# Altium schematic sheet MCU_related.SchDoc(MCU_related)
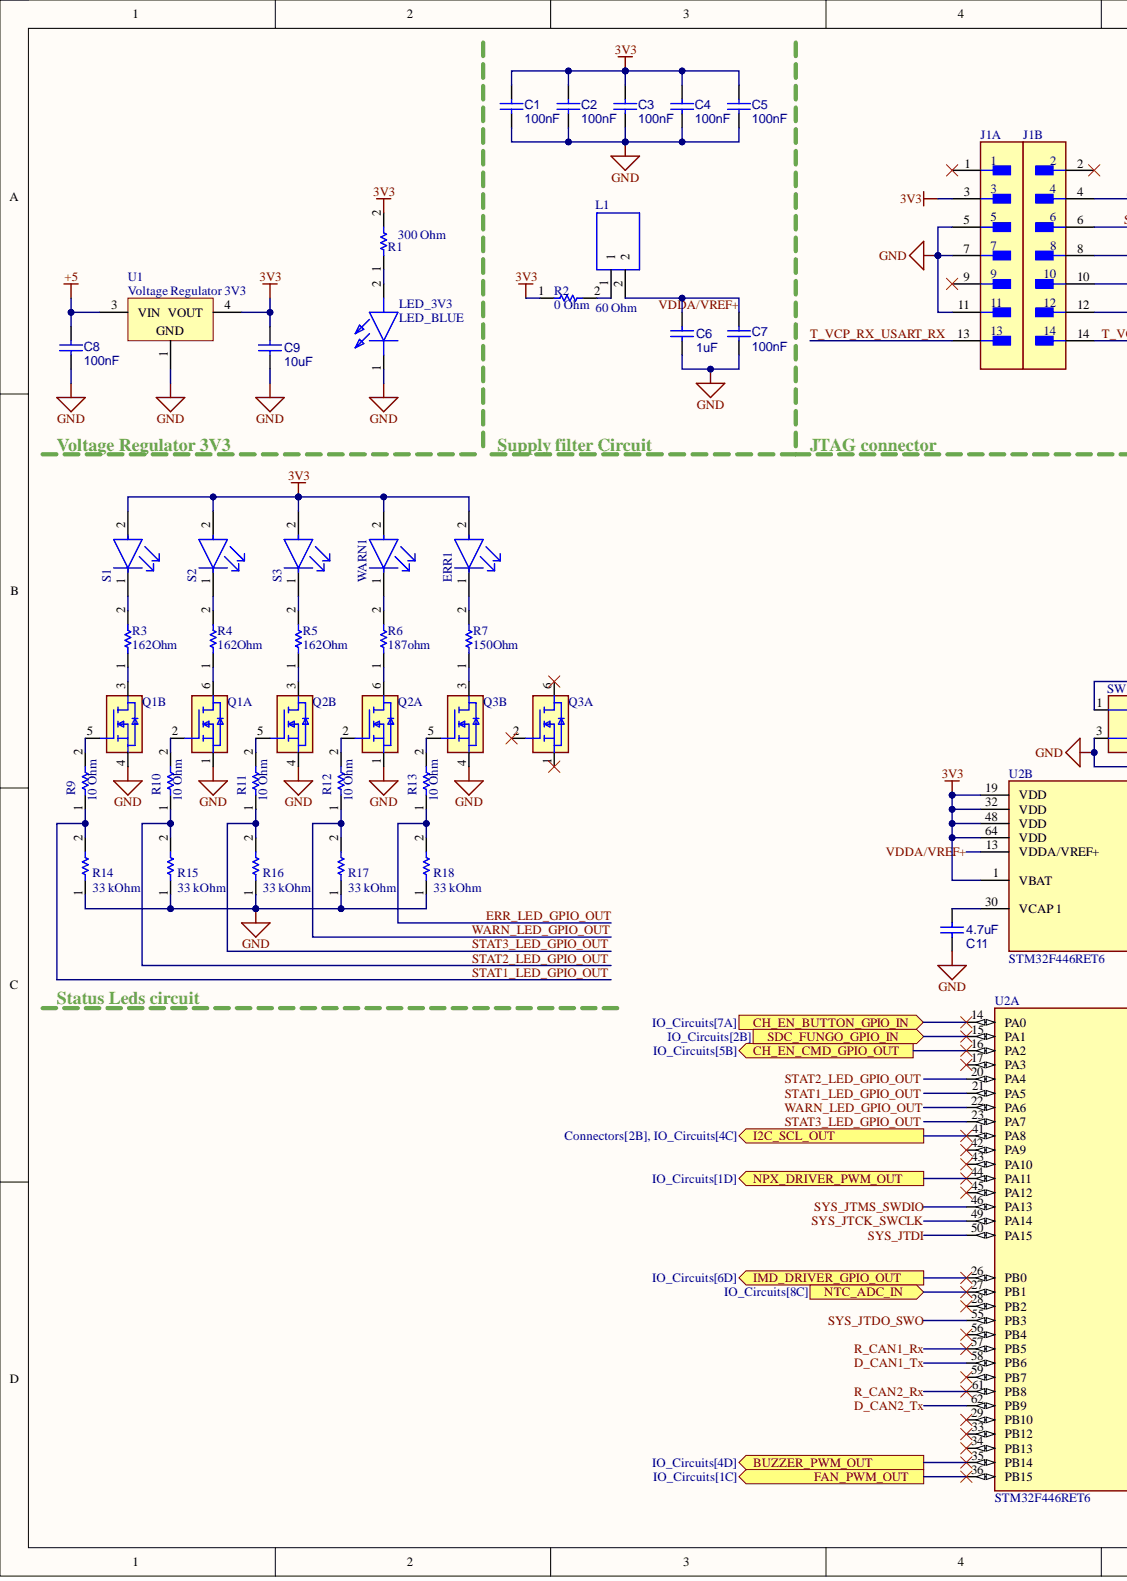
[diagram p0: MCU_related.SchDoc, left]
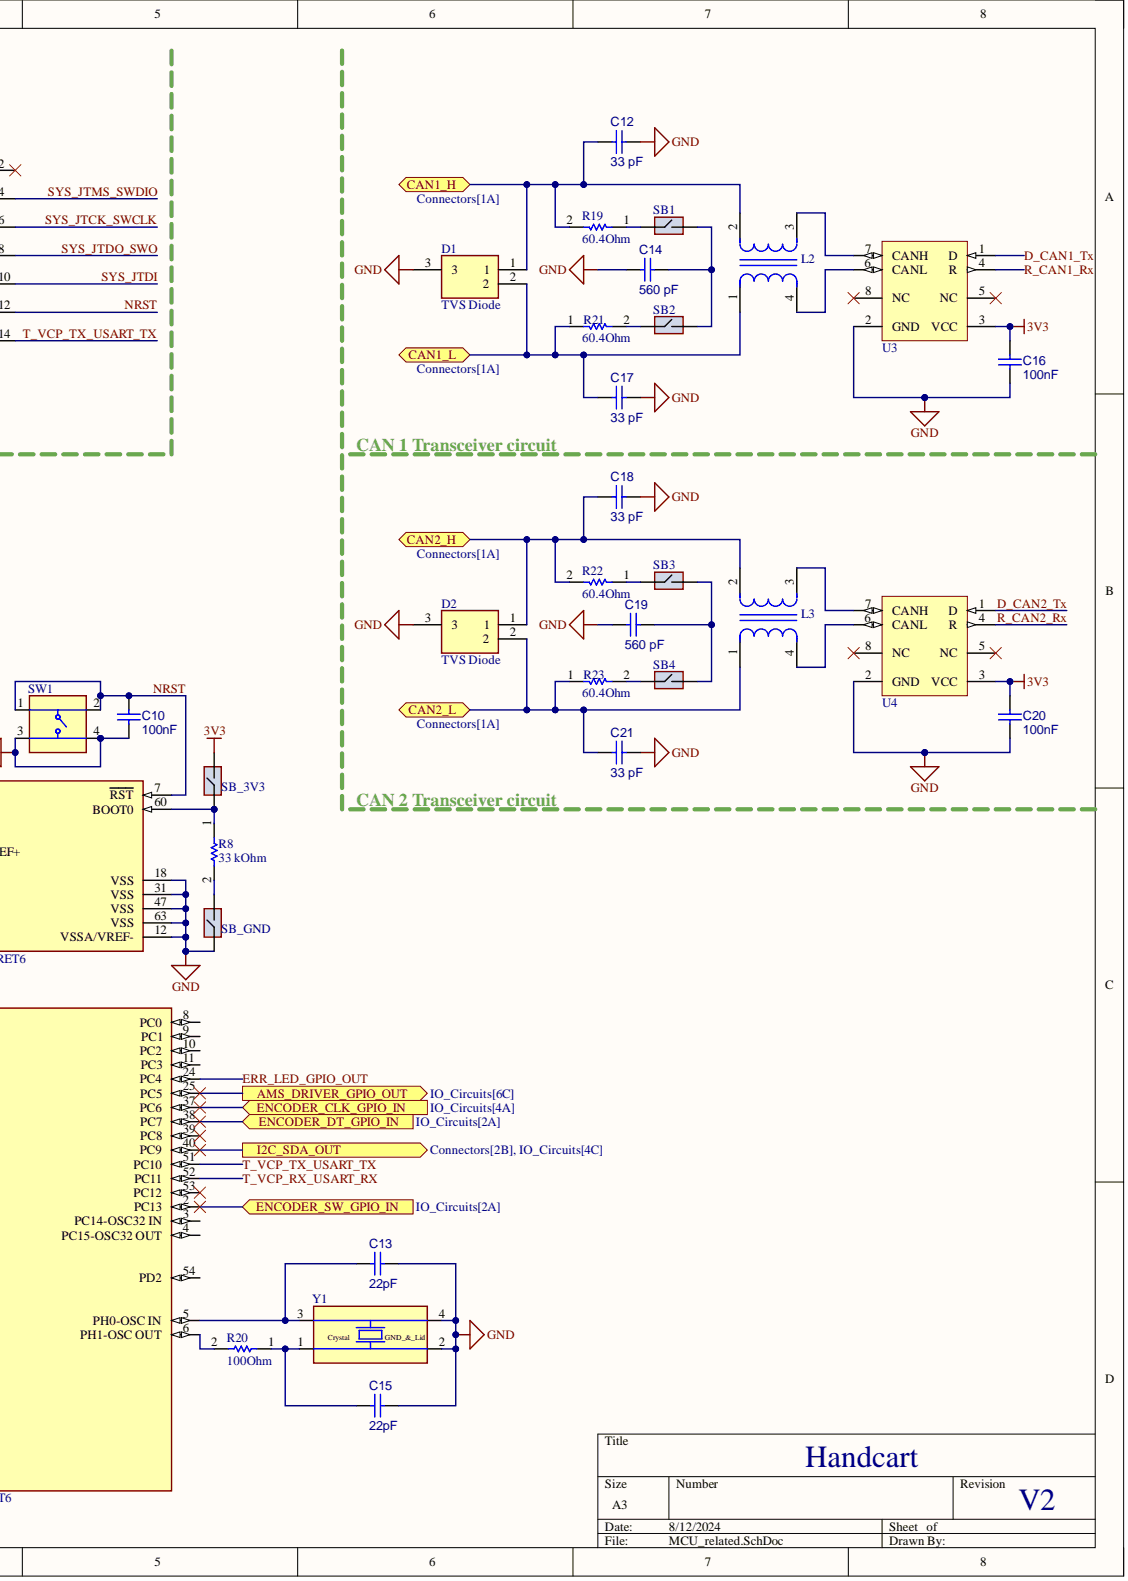
[diagram p1: MCU_related.SchDoc, right]

## Parts
C1  100nF
C2  100nF
C3  100nF
C4  100nF
C5  100nF
C6  1uF
C7  100nF
C8  100nF
C9  10uF
C10  100nF
C11  4.7uF
C12  33 pF
C13  22pF
C14  560 pF
C15  22pF
C16  100nF
C17  33 pF
C18  33 pF
C19  560 pF
C20  100nF
C21  33 pF
D1  TVS Diode
D2  TVS Diode
ERR1  RED  (value not drawn)
J1  FTSH-107-01-L-DV-K-A  (value not drawn)  (parts A,B)
L1  60 Ohm
L2  ACT45B-101-2P-TL003  (value not drawn)
L3  ACT45B-101-2P-TL003  (value not drawn)
LED_3V3  LED_BLUE
Q1  SI1926DL-T1-GE3  (value not drawn)  (parts A,B)
Q2  SI1926DL-T1-GE3  (value not drawn)  (parts A,B)
Q3  SI1926DL-T1-GE3  (value not drawn)  (parts A,B)
R1  300 Ohm
R2  0 Ohm
R3  162Ohm
R4  162Ohm
R5  162Ohm
R6  187ohm
R7  150Ohm
R8  33 kOhm
R9  10 Ohm
R10  10 Ohm
R11  10 Ohm
R12  10 Ohm
R13  10 Ohm
R14  33 kOhm
R15  33 kOhm
R16  33 kOhm
R17  33 kOhm
R18  33 kOhm
R19  60.4Ohm
R20  100Ohm
R21  60.4Ohm
R22  60.4Ohm
R23  60.4Ohm
S1  GREEN  (value not drawn)
S2  GREEN  (value not drawn)
S3  GREEN  (value not drawn)
SB1  SolderBridge_0805  (value not drawn)  (no BOM)
SB2  SolderBridge_0805  (value not drawn)  (no BOM)
SB3  SolderBridge_0805  (value not drawn)  (no BOM)
SB4  SolderBridge_0805  (value not drawn)  (no BOM)
SB_3V3  SolderBridge_0805  (value not drawn)  (no BOM)
SB_GND  SolderBridge_0805  (value not drawn)  (no BOM)
SW1  RKC2SJF170SMTR_LFS  (value not drawn)
U1  Voltage Regulator 3V3
U2  STM32F446RET6  (parts A,B)
U3  SN65HVD232DRG4  [SN65HVD232]  (value not drawn)
U4  SN65HVD232DRG4  [SN65HVD232]  (value not drawn)
WARN1  YELLOW  (value not drawn)
Y1  830055663  (value not drawn)

## Ports
AMS_DRIVER_GPIO_OUT, BUZZER_PWM_OUT, CAN1_H, CAN1_L, CAN2_H, CAN2_L, CH_EN_BUTTON_GPIO_IN, CH_EN_CMD_GPIO_OUT, ENCODER_CLK_GPIO_IN, ENCODER_DT_GPIO_IN, ENCODER_SW_GPIO_IN, FAN_PWM_OUT, I2C_SCL_OUT, I2C_SDA_OUT, IMD_DRIVER_GPIO_OUT, NPX_DRIVER_PWM_OUT, NTC_ADC_IN, SDC_FUNGO_GPIO_IN

## Nets
3V3: C1.2 C2.2 C3.2 C4.2 C5.2 C9.2 C16.1 C20.1 ERR1.2 J1.3 R1.2 R2.1 S1.2 S2.2 S3.2 SB_3V3.2 U1.4 U2.1(VBAT) U2.19(VDD) U2.32(VDD) U2.48(VDD) U2.64(VDD) U3.3(VCC) U4.3(VCC) WARN1.2
+5: C8.2 U1.3
GND: C1.1 C2.1 C3.1 C4.1 C5.1 C6.1 C7.1 C8.1 C9.1 C10.1 C11.1 C12.1 C13.1 C14.1 C15.2 C16.2 C17.1 C18.1 C19.1 C20.2 C21.1 D1.3 D2.3 J1.5 J1.7 J1.11 LED_3V3.1 Q1.1 Q1.4 Q2.1 Q2.4 Q3.4 R14.1 R15.1 R16.1 R17.1 R18.1 SB_GND.1 SW1.3 SW1.4 U1.1 U2.12(VSSA/VREF-) U2.18(VSS) U2.31(VSS) U2.47(VSS) U2.63(VSS) U3.2(GND) U4.2(GND) Y1.2 Y1.4
AMS_DRIVER_GPIO_OUT: U2.25(PC5) ; port AMS_DRIVER_GPIO_OUT
BUZZER_PWM_OUT: U2.35(PB14) ; port BUZZER_PWM_OUT
CAN1_H: C12.2 D1.1 L2.2 R19.2 ; port CAN1_H
CAN1_L: C17.2 D1.2 L2.1 R21.1 ; port CAN1_L
CAN2_H: C18.2 D2.1 L3.2 R22.2 ; port CAN2_H
CAN2_L: C21.2 D2.2 L3.1 R23.1 ; port CAN2_L
CH_EN_BUTTON_GPIO_IN: U2.14(PA0) ; port CH_EN_BUTTON_GPIO_IN
CH_EN_CMD_GPIO_OUT: U2.16(PA2) ; port CH_EN_CMD_GPIO_OUT
D_CAN1_Tx: U2.58(PB6) U3.1(D)
D_CAN2_Tx: U2.62(PB9) U4.1(D)
ENCODER_CLK_GPIO_IN: U2.37(PC6) ; port ENCODER_CLK_GPIO_IN
ENCODER_DT_GPIO_IN: U2.38(PC7) ; port ENCODER_DT_GPIO_IN
ENCODER_SW_GPIO_IN: U2.2(PC13) ; port ENCODER_SW_GPIO_IN
ERR_LED_GPIO_OUT: R13.1 R18.2 U2.24(PC4)
FAN_PWM_OUT: U2.36(PB15) ; port FAN_PWM_OUT
I2C_SCL_OUT: U2.41(PA8) ; port I2C_SCL_OUT
I2C_SDA_OUT: U2.40(PC9) ; port I2C_SDA_OUT
IMD_DRIVER_GPIO_OUT: U2.26(PB0) ; port IMD_DRIVER_GPIO_OUT
NPX_DRIVER_PWM_OUT: U2.44(PA11) ; port NPX_DRIVER_PWM_OUT
NRST: C10.2 J1.12 SW1.1 SW1.2 U2.7(RST)
NTC_ADC_IN: U2.27(PB1) ; port NTC_ADC_IN
R_CAN1_Rx: U2.57(PB5) U3.4(R)
R_CAN2_Rx: U2.61(PB8) U4.4(R)
SDC_FUNGO_GPIO_IN: U2.15(PA1) ; port SDC_FUNGO_GPIO_IN
STAT1_LED_GPIO_OUT: R9.1 R14.2 U2.21(PA5)
STAT2_LED_GPIO_OUT: R10.1 R15.2 U2.20(PA4)
STAT3_LED_GPIO_OUT: R11.1 R16.2 U2.23(PA7)
SYS_JTCK_SWCLK: J1.6 U2.49(PA14)
SYS_JTDI: J1.10 U2.50(PA15)
SYS_JTDO_SWO: J1.8 U2.55(PB3)
SYS_JTMS_SWDIO: J1.4 U2.46(PA13)
T_VCP_RX_USART_RX: J1.13 U2.52(PC11)
T_VCP_TX_USART_TX: J1.14 U2.51(PC10)
VDDA/VREF+: C6.2 C7.2 L1.2 U2.13(VDDA/VREF+)
WARN_LED_GPIO_OUT: R12.1 R17.2 U2.22(PA6)
NetC11_2: C11.2 U2.30(VCAP_1)
NetC13_2: C13.2 U2.5(PH0-OSC_IN) Y1.3
NetC14_2: C14.2 SB1.2 SB2.2
NetC15_1: C15.1 R20.1 Y1.1
NetC19_2: C19.2 SB3.2 SB4.2
NetERR1_1: ERR1.1 R7.2
NetL1_1: L1.1 R2.2
NetL2_3: L2.3 U3.7(CANH)
NetL2_4: L2.4 U3.6(CANL)
NetL3_3: L3.3 U4.7(CANH)
NetL3_4: L3.4 U4.6(CANL)
NetLED_3V3_2: LED_3V3.2 R1.1
NetQ1_2: Q1.2 R10.2
NetQ1_3: Q1.3 R3.1
NetQ1_5: Q1.5 R9.2
NetQ1_6: Q1.6 R4.1
NetQ2_2: Q2.2 R12.2
NetQ2_3: Q2.3 R5.1
NetQ2_5: Q2.5 R11.2
NetQ2_6: Q2.6 R6.1
NetQ3_3: Q3.3 R7.1
NetQ3_5: Q3.5 R13.2
NetR3_2: R3.2 S1.1
NetR4_2: R4.2 S2.1
NetR5_2: R5.2 S3.1
NetR6_2: R6.2 WARN1.1
NetR8_1: R8.1 SB_3V3.1 U2.60(BOOT0)
NetR8_2: R8.2 SB_GND.2
NetR19_1: R19.1 SB1.1
NetR20_2: R20.2 U2.6(PH1-OSC_OUT)
NetR21_2: R21.2 SB2.1
NetR22_1: R22.1 SB3.1
NetR23_2: R23.2 SB4.1

Not connected: J1 1 2 9; Q3 1 2 6; U2 3(PC14-OSC32_IN) 4(PC15-OSC32_OUT) 8(PC0) 9(PC1) 10(PC2) 11(PC3) 17(PA3) 28(PB2) 29(PB10) 33(PB12) 34(PB13) 39(PC8) 42(PA9) 43(PA10) 45(PA12) 53(PC12) 54(PD2) 56(PB4) 59(PB7); U3 5(NC) 8(NC); U4 5(NC) 8(NC)

## Library data of the parts
C1-C5 C7 C8 C10 = CMP-001-00085-5: VJ0805Y104KBABE31; Tech: SMD; Component Kind: Standard; ComponentLink1Description: Website; Package: 0805; Library Name: EMEA- Squadra Corse PoliTO-  Politecnico di Torino
C6 = CMP-001-00085-5: VJ0805Y105KXXTW1BC; Tech: SMD; Component Kind: Standard; ComponentLink1Description: Website; Package: 0805; Library Name: EMEA- Squadra Corse PoliTO-  Politecnico di Torino
C9 = CMP-001-00085-5: GRM21BR71A106KA73K; Tech: SMD; Component Kind: Standard; ComponentLink1Description: Website; Package: 0805; Library Name: EMEA- Squadra Corse PoliTO-  Politecnico di Torino
C11 = CMP-001-00085-5: GCJ21BR71C475KA01L; Tech: SMD; Component Kind: Standard; ComponentLink1Description: Website; Package: 0805; Library Name: EMEA- Squadra Corse PoliTO-  Politecnico di Torino
C12 C17 C18 C21 = CMP-001-00085-5: VJ0805A330JXACW1BC; Tech: SMD; Component Kind: Standard; ComponentLink1Description: Website; Package: 0805; Library Name: EMEA- Squadra Corse PoliTO-  Politecnico di Torino
C13 C15 = CMP-001-00085-5: VJ0805A220KXACW1BC; Tech: SMD; Component Kind: Standard; ComponentLink1Description: Website; Package: 0805; Library Name: EMEA- Squadra Corse PoliTO-  Politecnico di Torino
C14 C19 = CMP-001-00085-5: VJ0805Y561JXXPW1BC; Tech: SMD; Component Kind: Standard; ComponentLink1Description: Website; Package: 0805; Library Name: EMEA- Squadra Corse PoliTO-  Politecnico di Torino
C16 C20 = CMP-001-00085-5: VJ0805Y104MXATW1BC; Tech: SMD; Component Kind: Standard; ComponentLink1Description: Website; Package: 0805; Library Name: EMEA- Squadra Corse PoliTO-  Politecnico di Torino
D1 D2 = CMP-030-000036-3: ESDCAN24-2BLY; Tech: SMD; Component Kind: Standard; Package: SOT-23-3; Library Name: EMEA- Squadra Corse PoliTO-  Politecnico di Torino
ERR1 = CMP-007-00036-2: VLMS1300-GS08; Tech: SMD; Component Kind: Standard; Package: 0603; Library Name: EMEA- Squadra Corse PoliTO-  Politecnico di Torino
J1 = CMP-02766-001414-1: CONN HEADER SMD 14POS 1.27MM; Gender: Male; Number of Contacts: 14; Component Kind: Standard; Contact Material: Bronze; Contact Plating: Gold; Lead Free: Lead Free; Library Name: Altium Content Vault; Number of Rows: 2; Pitch: 1.27mm; RoHS Compliant: Yes; Termination: Solder
L1 = CMP-056-000000-2: Ferrite Beads Multi-Layer High Current 60Ohm 25% 100MHz 3A 30mOhm DCR 0805 T/R; Manufacturer_Name: Vishay; Manufacturer_Part_Number: ILHB0805ER600V; Geometry.Height: 1.1mm; Component Kind: Standard; Datasheet Link: https://www.arrow.com/en/products/ilhb0805er600v/vishay; Design Item ID: ILHB0805ER600V; Mouser Part Number: 70-ILHB0805ER600V; Mouser Price/Stock: https://www.mouser.co.uk/ProductDetail/Vishay-Dale/ILHB0805ER600V?qs=nDC8RkArV2CRAw2z9yWdfQ%3D%3D; Source: ILHB0805ER600V.SchLib; Library Name: EMEA- Squadra Corse PoliTO-  Politecnico di Torino
L2 L3 = CMP-08256-000007-1: CMC 100UH 150MA 2LN SMD AEC-Q200; Manufacturer: TDK; Automotive Grade: Grade 0; Impedance At Frequency: 5800Ω @ 10MHz; Rms Current: 0.15A; Series Resistance: 2Ω; Test Frequency: 100kHz; Tolerance: +50/-30%; Automotive: Yes; Category: Ind; Component Kind: Standard; ComponentLink2Description: Datasheet; ComponentLink2URL: https://product.tdk.com/info/en/catalog/datasheets/cmf_automotive_signal_act45b_en.pdf; Composition: Wirewound; Device Class L1: Passive Components; Device Class L2: EMI / RFI Components; Device Class L3: Common Mode Chokes; Digikey Description: CMC 100UH 150MA 2LN SMD AEC-Q200; Height: 3mm; Inductance: 100uH; Lead Free: Yes; Library Name: Altium Content Vault; Manufacturer Part Number: ACT45B-101-2P-TL003; Mouser Description: Common Mode Chokes / Filters 5000ohms 100uH 150mA CAN-BUS AEC-Q200; Octopart: https://octopart.com/act45b-101-2p-tl003-tdk-11353565; Package: ACT45B; RoHS: TRUE; Temperature: -40°C to +150°C; Temperature Range High: +150°C; Temperature Range Low: -40°C; Value: 100uH
LED_3V3 = CMP-031-000016-1: APT2012LVBC_D; Manufacturer_Name: Kingbright; Manufacturer_Part_Number: APT2012LVBC/D; Tech: SMD; Arrow Part Number: APT2012LVBC/D; Arrow Price/Stock: https://www.arrow.com/en/products/apt2012lvbcd/kingbright; Component Kind: Standard; ComponentLink1Description: Website; ComponentLink1URL: https://www.mouser.it/ProductDetail/Kingbright/APT2012LVBC-D?qs=6oMev5NRZMGErJYT6KZLXg%3D%3D; Datasheet Link: https://componentsearchengine.com/Datasheets/1/APT2012LVBC_D.pdf; Design Item ID: APT2012LVBC_D; Height: 0.85mm; Mouser Part Number: 604-APT2012LVBCD; Mouser Price/Stock: https://www.mouser.co.uk/ProductDetail/Kingbright/APT2012LVBC-D?qs=6oMev5NRZMGErJYT6KZLXg%3D%3D; Package: 0805; Source: SamacSys.SchLib; Library Name: EMEA- Squadra Corse PoliTO-  Politecnico di Torino
Q1-Q3 = CMP-009-000004-2: MOSFET (N-Channel); Manufacturer_Name: Vishay; Arrow Part Number: SI1926DL-T1-GE3; Arrow Price/Stock: https://www.arrow.com/en/products/si1926dl-t1-ge3/vishay; Component Kind: Standard; Datasheet Link: https://www.vishay.com/docs/73684/si1926dl.pdf; Design Item ID: SI1926DL-T1-GE3; Height: 1.1mm; Manufacturer_Part_Number: SI1926DL-T1-GE3; Mouser Part Number: 78-SI1926DL-T1-GE3; Mouser Price/Stock: https://www.mouser.com/Search/Refine.aspx?Keyword=78-SI1926DL-T1-GE3; Source: SamacSys.SchLib; Library Name: EMEA- Squadra Corse PoliTO-  Politecnico di Torino
R1 = CMP-009-00179-5: CRCW0603300RFKEA; Tech: SMD; Component Kind: Standard; ComponentLink1Description: Website; Package: 0603; Library Name: EMEA- Squadra Corse PoliTO-  Politecnico di Torino
R2 = CMP-009-00179-5: CRCW12060000Z0EC; Tech: SMD; Component Kind: Standard; ComponentLink1Description: Website; Package: 0603; Library Name: EMEA- Squadra Corse PoliTO-  Politecnico di Torino
R3-R5 = CMP-009-00179-5: CRCW0603162RFKEA; Tech: SMD; Component Kind: Standard; ComponentLink1Description: Website; Package: 0603; Library Name: EMEA- Squadra Corse PoliTO-  Politecnico di Torino
R6 = CMP-009-00179-5: CRCW0603187RFKEA; Tech: SMD; Component Kind: Standard; ComponentLink1Description: Website; Package: 0603; Library Name: EMEA- Squadra Corse PoliTO-  Politecnico di Torino
R7 = CMP-009-00179-5: CRCW0603150RFKEAHP; Tech: SMD; Component Kind: Standard; ComponentLink1Description: Website; Package: 0603; Library Name: EMEA- Squadra Corse PoliTO-  Politecnico di Torino
R8 R14-R18 = CMP-009-00179-5: RCS060333K0FKEA; Tech: SMD; Component Kind: Standard; ComponentLink1Description: Website; Package: 0603; Library Name: EMEA- Squadra Corse PoliTO-  Politecnico di Torino
R9-R13 = CMP-009-00179-5: CRCW060310R0FKEAHP; Tech: SMD; Component Kind: Standard; ComponentLink1Description: Website; Package: 0603; Library Name: EMEA- Squadra Corse PoliTO-  Politecnico di Torino
R19 R21-R23 = CMP-009-00179-5: CRCW060360R4FKEA; Tech: SMD; Component Kind: Standard; ComponentLink1Description: Website; Package: 0603; Library Name: EMEA- Squadra Corse PoliTO-  Politecnico di Torino
R20 = CMP-009-00179-5: CRCW0603100RFKEBC; Tech: SMD; Component Kind: Standard; ComponentLink1Description: Website; Package: 0603; Library Name: EMEA- Squadra Corse PoliTO-  Politecnico di Torino
S1-S3 = CMP-007-00036-2: VLMTG1401-GS08; Tech: SMD; Component Kind: Standard; Package: 0603; Library Name: EMEA- Squadra Corse PoliTO-  Politecnico di Torino
SB1-SB4 SB_3V3 SB_GND = CMP-00006-5: 0805 Solder Bridge; Library Name: EMEA- Squadra Corse PoliTO-  Politecnico di Torino
SW1 = CMP-011-000004-5: Tactile Switches; Manufacturer_Name: C & K COMPONENTS; Manufacturer_Part_Number: RKC2SJF170SMTR LFS; Arrow Part Number: RKC2SJF170SMTR LFS; Arrow Price/Stock: https://www.arrow.com/en/products/rkc2sjf170smtr-lfs/ck?region=nac; Component Kind: Standard; Datasheet Link: https://www.ckswitches.com/media/3470/ck_rkc2_datasheet.pdf; Design Item ID: RKC2SJF170SMTR_LFS; Height: 1.9mm; Mouser Part Number: 611-RKC2SJF170SMRLFS; Mouser Price/Stock: https://www.mouser.co.uk/ProductDetail/CK/RKC2SJF170SMTR-LFS?qs=XAiT9M5g4x%252BRRI9derenng%3D%3D; Source: SamacSys.SchLib; Library Name: EMEA- Squadra Corse PoliTO-  Politecnico di Torino
U1 = CMP-0244-00089-1: LD1117DT33CTR; Tech: SMD; Component Kind: Standard; ComponentLink1Description: Manufacturer URL; ComponentLink1URL: http://www.st.com; ComponentLink2Description: Datasheet; ComponentLink2URL: http://www.st.com/internet/com/TECHNICAL_RESOURCES/TECHNICAL_LITERATURE/DATASHEET/CD00000544.pdf; ComponentLink3Description: Website; ComponentLink3URL: https://www.mouser.it/ProductDetail/STMicroelectronics/LD1117DT33CTR?qs=rUxiu3TV3%2FNm%2FOyb7vJzpg%3D%3D; DatasheetVersion: 1/11/2011; Library Name: Altium Content Vault; PackageDescription: 3-Pin Transistor Outline Package 2.28 mm Pitch, 9.7 mm Lead Span (DPAK); PackageReference: TO-252; PackageVersion: 2011
U2 = CMP-12105-000007-1: IC MCU 32BIT 512KB FLASH 64LQFP; Manufacturer: ST; Core Architecture: ARM Cortex-M4; Data Bus Width: 32bits; Frequency: 180MHz; Interface: I2C,SPI,UART,USART,CAN,USB,LIN,IrDA,I2S,Other; Max Junction Temp: +105°C; Max Supply Voltage: 3.6V; Memory Size: 512KBytes; Min Supply Voltage: 1.7V; Number Of A/D Converters: 3; Number Of Adc Channels: 16; Number Of Cores: 1; Number Of I2C Channels: 4; Number Of I/Os: 50; Number Of Pwm Channels: 32; Number Of Timers/Counters: 14; Number Of Uart Channels: 6; Number Of Usb Channels: 2; Ram Size: 128KBytes; Standoff Height: 0.05mm; Ambient Temperature Range High: +85°C; Ambient Temperature Range Low: -40°C; Automotive: No; Category: IC; Component Kind: Standard; ComponentLink2Description: Datasheet; ComponentLink2URL: https://www.st.com/en/microcontrollers-microprocessors/stm32f446re.html; Device Class L1: Integrated Circuits (ICs); Device Class L2: Embedded Processors and Controllers; Device Class L3: Microcontrollers; Digikey Description: IC MCU 32BIT 512KB FLASH 64LQFP; Height: 1.6mm; Ipc Land Pattern Name: QFP50P1200X1200X160-64; Lead Free: Yes; Library Name: Altium Content Vault; Manufacturer Part Number: STM32F446RET6; Memory Type: FLASH; Mouser Description: ARM Microcontrollers - MCU High-performance and DSP with FP, ARM Cortex-M4 MCU with 512 Kbytes Flash, 180 MHz CPU, Art Accelerator, SDRAM; Number Of Spi Channels: 4; Octopart: https://octopart.com/stm32f446ret6-stmicroelectronics-52642920; Package: LQFP64-5W; RoHS: TRUE; Temperature: -40°C to +105°C; Temperature Range High: +105°C; Temperature Range Low: -40°C
U3 U4 = CMP-0315-00080-1: 3.3 V Can Transceiver, 17 mA, -40 to 85 degC, 8-pin SOIC (D), Green (RoHS & no Sb/Br); Manufacturer: Texas Instruments; Drivers Per Package: 1; ICC(Max)(mA): 17; Rating: Catalog; Supply Voltage(s)(V): 3.3; Transient Pulse Protection(V): -25 to 25; Code_JEDEC: MS-012AA; Component Kind: Standard; ComponentLink1Description: Datasheet; ComponentLink1URL: http://www.ti.com/general/docs/lit/getliterature.tsp?genericPartNumber=SN65HVD232&fileType=pdf; DatasheetVersion: SLOS346K; Eco-Plan: Green (RoHS & no Sb/Br); Isolated: No; Library Name: Altium Content Vault; Mounting Technology: Surface Mount; PackageDescription: 8-Pin Plastic Small Outline Integrated Circuit, 4.9 x 3.9 mm, Pitch 1.27 mm Pitch; PackageReference: D8; PackageVersion: revM, Jun-2011; PartNumber: SN65HVD232DRG4; Receivers Per Package: 1; SubFamily: CAN
WARN1 = CMP-007-00036-2: VLMG1300-GS08; Tech: SMD; Component Kind: Standard; Package: 0603; Library Name: EMEA- Squadra Corse PoliTO-  Politecnico di Torino
Y1 = CMP-004-000011-5: Crystals WE-XTAL 8.0MHz 30ppm 5.0 x 3.2mm; Manufacturer_Name: Wurth Elektronik; Component Kind: Standard; Datasheet Link: https://www.we-online.com/catalog/datasheet/830055663.pdf; Design Item ID: 830055663; Height: 1mm; Manufacturer_Part_Number: 830055663; Mouser Part Number: 710-830055663; Mouser Price/Stock: https://www.mouser.co.uk/ProductDetail/Wurth-Elektronik/830055663?qs=2WXlatMagcHXGqDkS%2FTHKQ%3D%3D; Source: SamacSys.SchLib; Library Name: EMEA- Squadra Corse PoliTO-  Politecnico di Torino
